# Altium schematic sheet DashboardDisplay_PSU_COM.SchDoc(DashboardDisplay_PSU_COM)
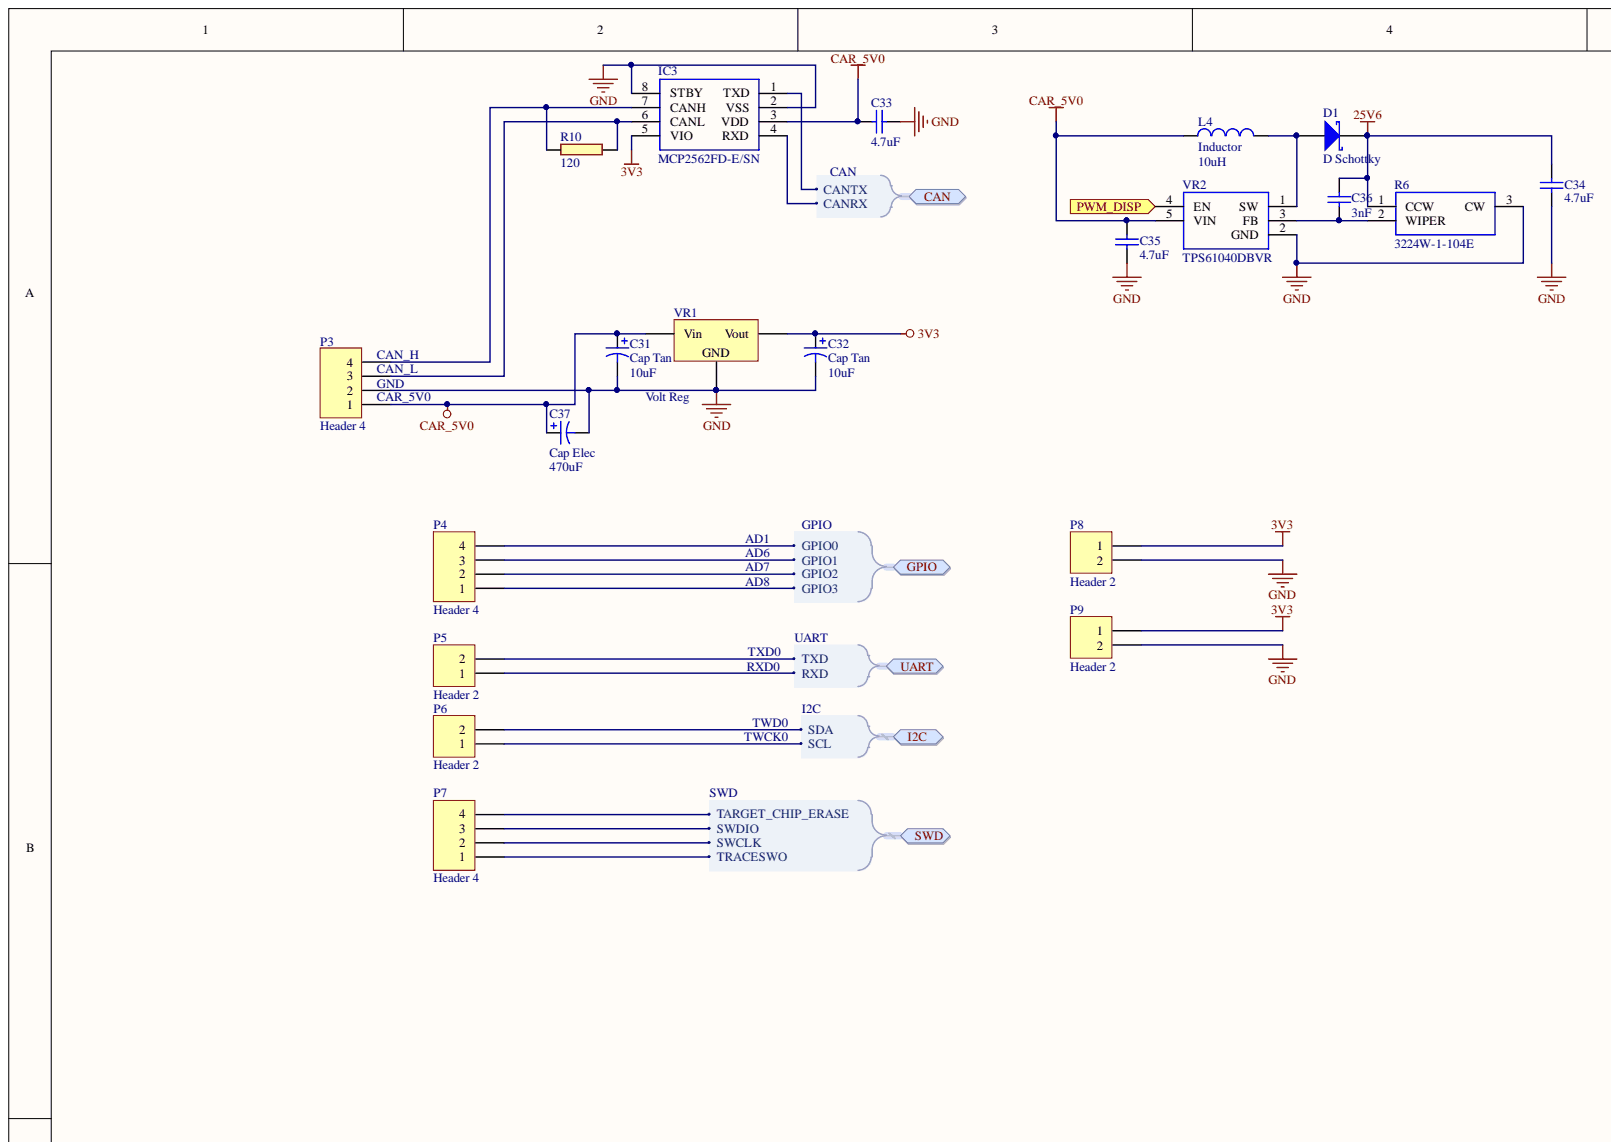
[diagram p0: DashboardDisplay_PSU_COM.SchDoc, top left]
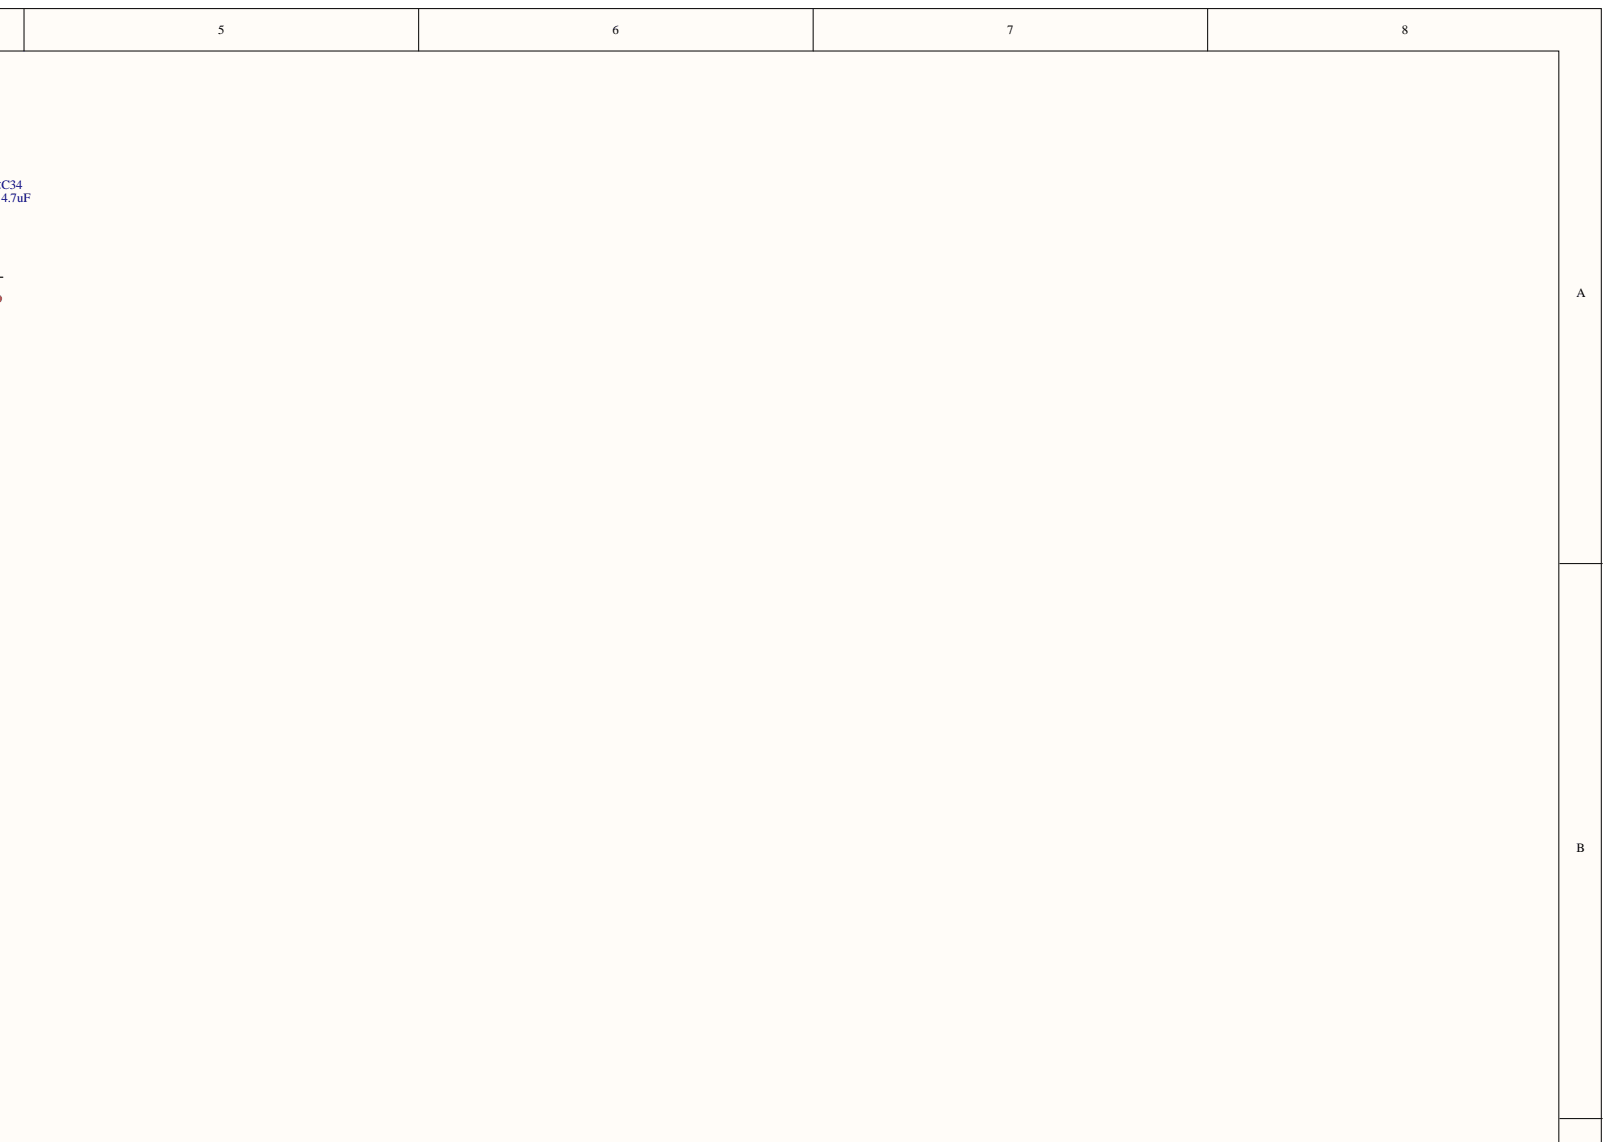
[diagram p1: DashboardDisplay_PSU_COM.SchDoc, top right]
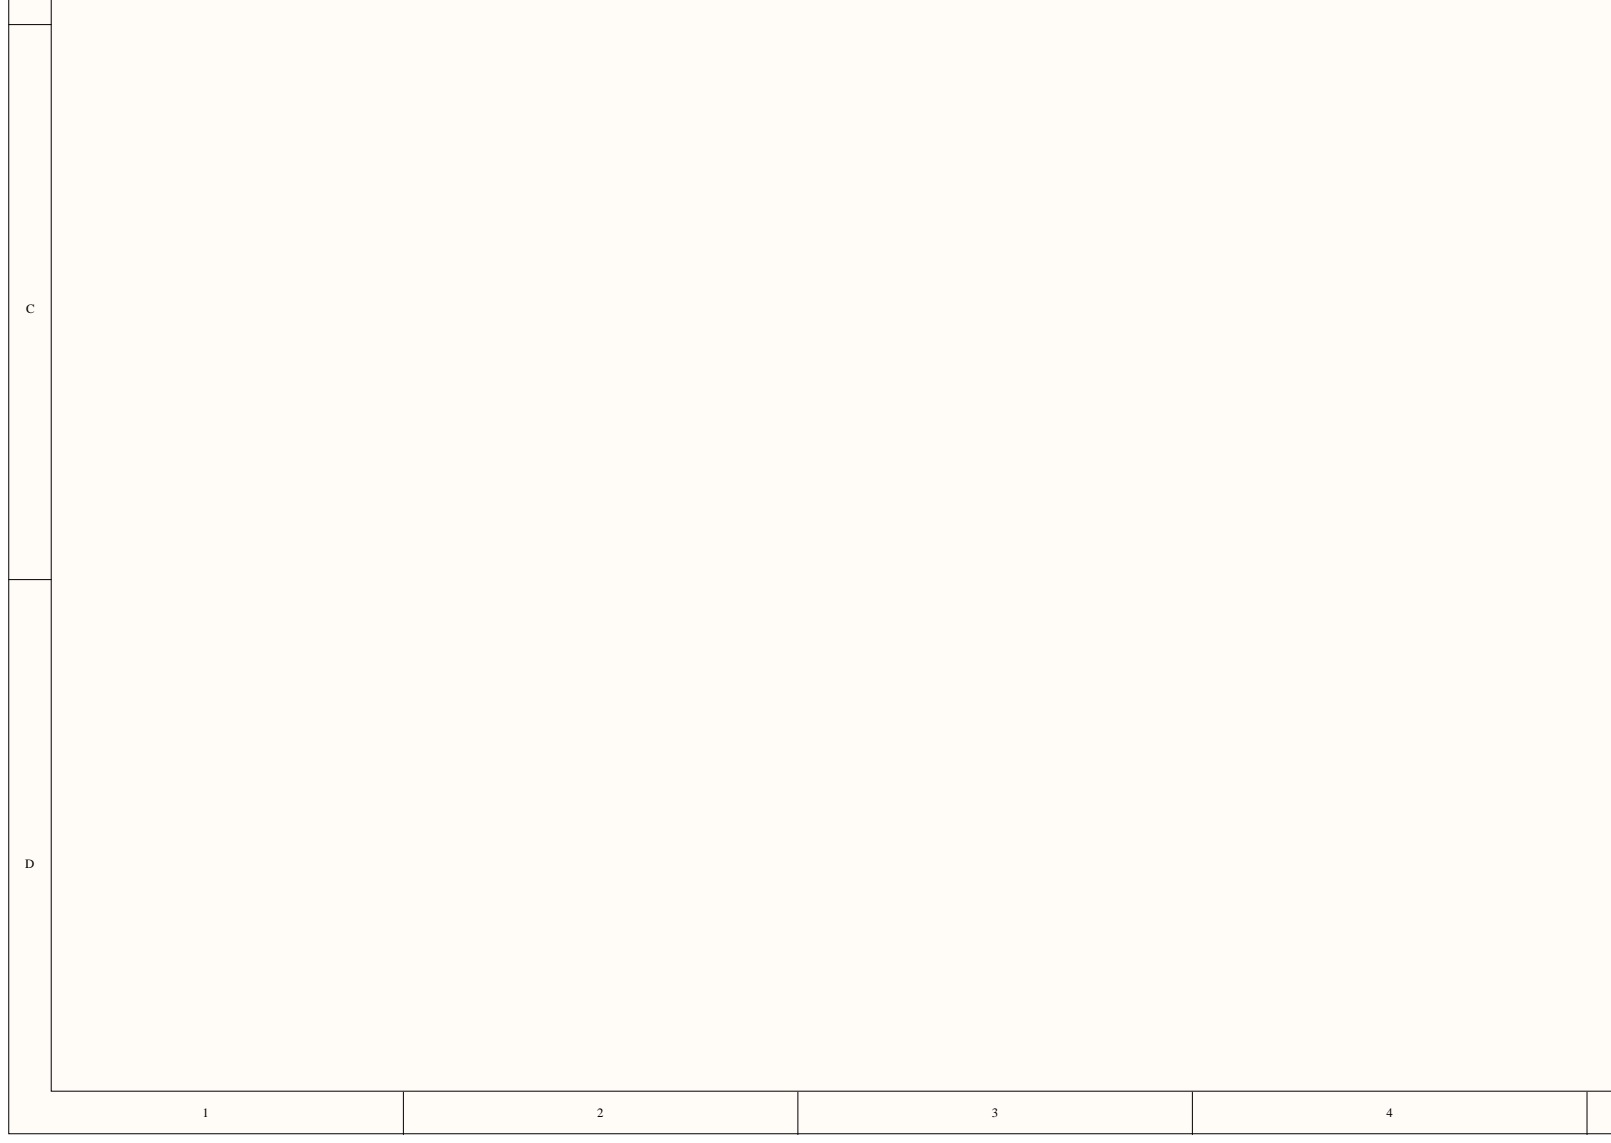
[diagram p2: DashboardDisplay_PSU_COM.SchDoc, bottom left]
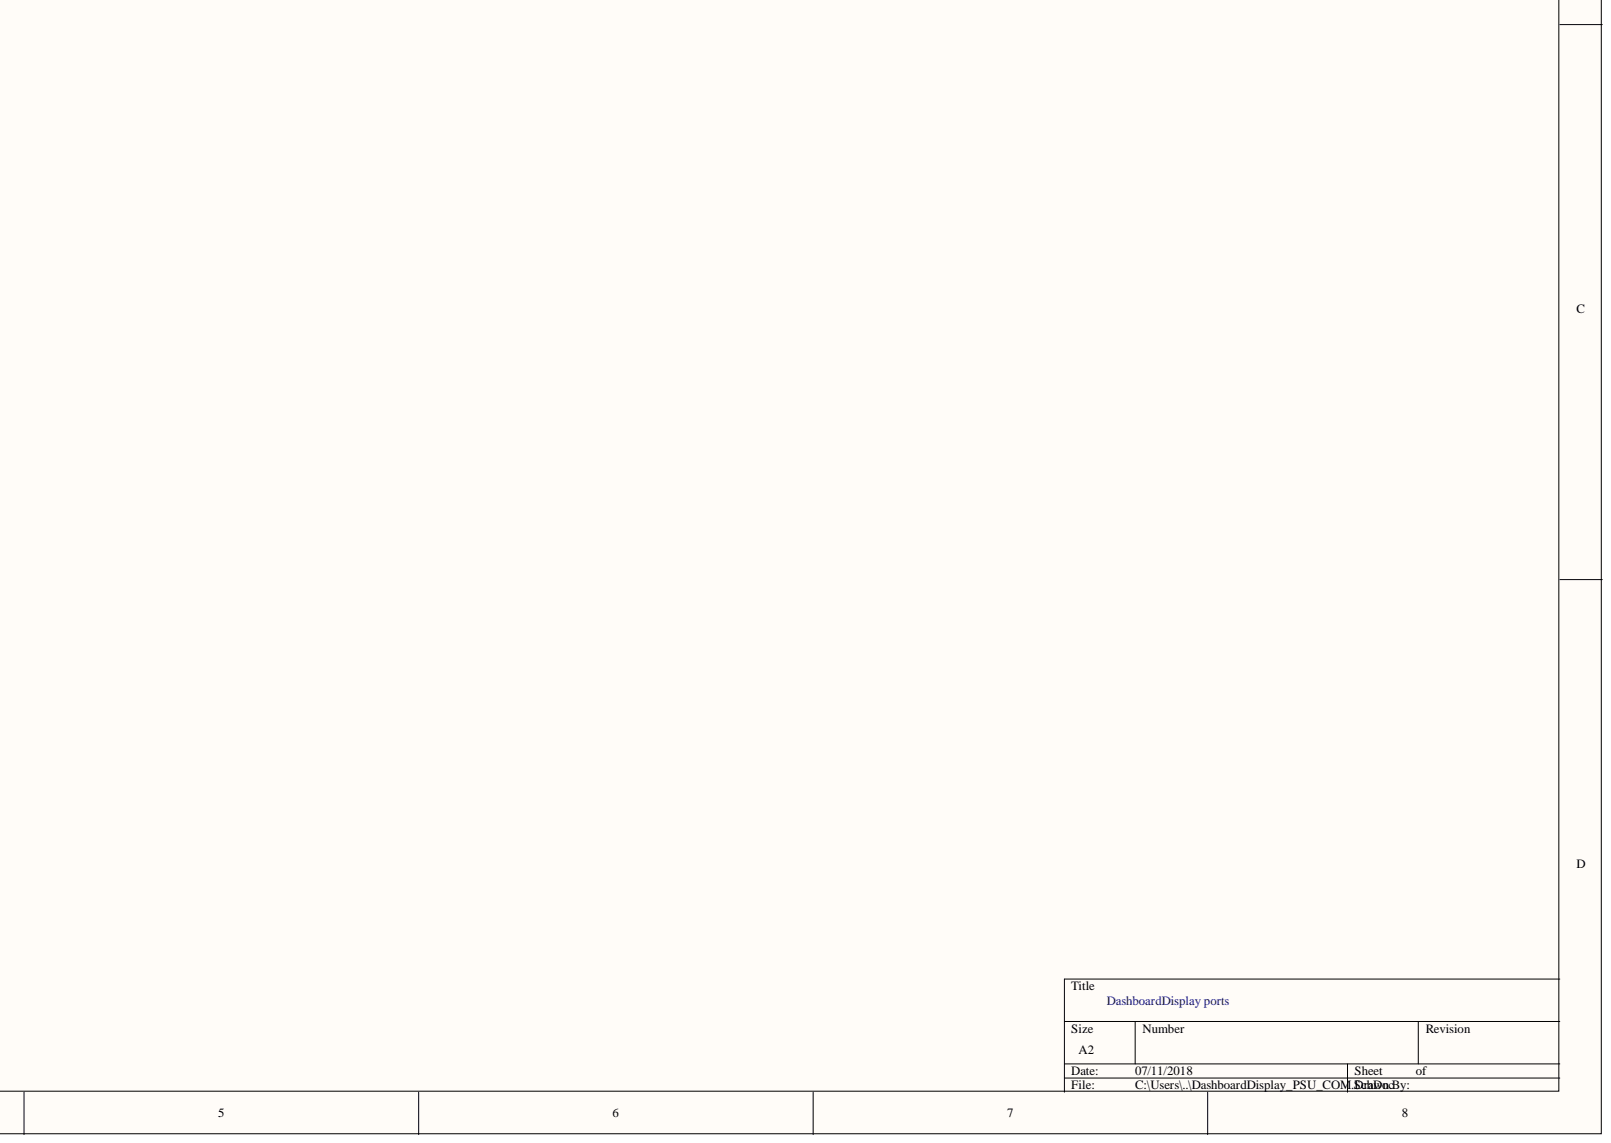
[diagram p3: DashboardDisplay_PSU_COM.SchDoc, bottom right]

## Parts
C31  Cap Tan  value 10uF  [C0805]
C32  Cap Tan  value 10uF  [C0805]
C33  value 4.7uF  [C1206]  (hidden comment: Cap Semi)
C34  value 4.7uF  [C1206]  (hidden comment: Cap Semi)
C35  value 4.7uF  [C1206]  (hidden comment: Cap Semi)
C36  value 3nF  [1608[0603]]  (hidden comment: Cap Semi)
C37  Cap Elec  value 470uF  [EEVFK2A151M]
D1  D Schottky  [SODFL350X110-2N]
IC3  MCP2562FD-E/SN  [SOIC127P600X175-8N]
L4  Inductor  value 10uH  [INDC3225X210N]
P3  Header 4  [SM04B-SRSS-TB(LFSN)]
P4  Header 4  [SM04B-SRSS-TB(LFSN)]
P5  Header 2  [SM02B-SRSS-TB]
P6  Header 2  [SM02B-SRSS-TB]
P7  Header 4  [SM04B-SRSS-TB(LFSN)]
P8  Header 2  [SM02B-SRSS-TB]
P9  Header 2  [SM02B-SRSS-TB]
R6  3224W-1-104E  [3224W-1-105E]
R10  value 120  [6-0805_L]
VR1  Volt Reg  [SOT223_L]
VR2  TPS61040DBVR  [SOT95P280X145-5N]

## Ports
CAN, CAN.CANRX, CAN.CANTX, GPIO, GPIO.GPIO0, GPIO.GPIO1, GPIO.GPIO2, GPIO.GPIO3, I2C, I2C.SCL, I2C.SDA, PWM_DISP, SWD, SWD.SWCLK, SWD.SWDIO, SWD.TARGET_CHIP_ERASE, SWD.TRACESWO, UART, UART.RXD, UART.TXD

## Nets
3V3: C32.1 IC3.5(VIO) P8.1 P9.1 VR1.2 VR1.4
25V6: C34.1 C36.2 D1.2 R6.1
CAR_5V0: C31.1 C33.2 C35.1 C37.1 IC3.3(VDD) L4.1 P3.1 VR1.3 VR2.5(VIN)
GND: C31.2 C32.2 C33.1 C34.2 C35.2 C37.2 IC3.2(VSS) IC3.8(STBY) P3.2 P3.5 P3.6 P4.5 P4.6 P5.3 P5.4 P6.3 P6.4 P7.5 P7.6 P8.2 P8.3 P8.4 P9.2 P9.3 P9.4 R6.3 VR1.1 VR2.2(GND)
PWM_DISP: VR2.4(EN) ; port PWM_DISP
NetC36_1: C36.1 R6.2 VR2.3(FB)
NetD1_1: D1.1 L4.2 VR2.1(SW)
NetIC3_1: IC3.1(TXD) ; port CAN
NetIC3_4: IC3.4(RXD) ; port CAN
NetIC3_6: IC3.6(CANL) P3.3 R10.2
NetIC3_7: IC3.7(CANH) P3.4 R10.1
NetP4_1: P4.1 ; port GPIO
NetP4_2: P4.2 ; port GPIO
NetP4_3: P4.3 ; port GPIO
NetP4_4: P4.4 ; port GPIO
NetP5_1: P5.1 ; port UART
NetP5_2: P5.2 ; port UART
NetP6_1: P6.1 ; port I2C
NetP6_2: P6.2 ; port I2C
NetP7_1: P7.1 ; port SWD
NetP7_2: P7.2 ; port SWD
NetP7_3: P7.3 ; port SWD
NetP7_4: P7.4 ; port SWD

## Library data of the parts
C31 C32 C37 = Cap Pol3: Polarized Capacitor (Surface Mount); Simulation: CAP; Signal Integrity: Cap Pol; Published: 8-Jun-2000; PackageReference: C0805; PackageDescription: Chip Capacitor; Library Name: Miscellaneous Devices.IntLib; LatestRevisionNote: IPC-7351 Footprint Added.; LatestRevisionDate: 29-May-2009; Component Kind: Standard; Publisher: Altium Limited
C33-C36 = Cap Semi: Capacitor (Semiconductor SIM Model); Simulation: CAP; Signal Integrity: Cap Semi; Published: 8-Jun-2000; PackageReference: C1206; PackageDescription: Chip Capacitor; Library Name: Miscellaneous Devices.IntLib; LatestRevisionNote: IPC-7351 Footprint Added.; LatestRevisionDate: 29-May-2009; Component Kind: Standard; Publisher: Altium Limited
D1 = D Schottky: Schottky Diode  MBR0530; Simulation: SKYDIODE; Signal Integrity: D Schottky; Published: 8-Jun-2000; PackageReference: SMB; PackageDescription: DO-214-AA/SMB; 2 C-Bend Leads; Body 5.3 x 3.6 mm, inc. leads (LxW); MPN: MBR0530; Library Name: Miscellaneous Devices.IntLib; LatestRevisionNote: Re-released for DXP Platform.; LatestRevisionDate: 17-Jul-2002; Component Kind: Standard; Code_JEDEC: DO-214-AA; Publisher: Altium Limited
IC3 = MCP2561-E_SN: CAN FD Transceiver 8Mbps 5V SOIC8 Microchip MCP2562FD-E/SN; Manufacturer_Part_Number: MCP2561-E/SN; Geometry.Height: 1.75mm; Allied_Number: 70415103; RS Price/Stock: http://uk.rs-online.com/web/p/products/8884871; RS Part Number: 8884871; Manufacturer_Name: Microchip; Library Name: MCP2561-E_SN.SchLib; Datasheet Link: http://uk.rs-online.com/web/p/products/7990216; Component Kind: Standard; Allied Price/Stock: https://www.alliedelec.com/microchip-technology-inc-mcp2561-e-sn/70415103/
L4 = Inductor: Inductor  LBC3225T100KRV; MPN: MLZ1608N100LT000; Value: 10uH; Code_IEC: 1005; Simulation: INDUCTOR; Signal Integrity: Inductor; Published: 8-Jun-2000; PackageReference: 0402-A; PackageDescription: Chip Inductor; Library Name: Miscellaneous Devices.IntLib; LatestRevisionNote: IPC-7351 Footprint Added.; LatestRevisionDate: 29-May-2009; Component Kind: Standard; Code_JEITA: 0402; Publisher: Altium Limited
P3 P4 P7 = Header 4: JST, 4-Pin; Signal Integrity: Connector; Library Name: Miscellaneous Connectors.IntLib; LatestRevisionNote: Re-released for DXP Platform.; LatestRevisionDate: 17-Jul-2002; Component Kind: Standard; Publisher: Altium Limited
P5 P6 P8 P9 = Header 2: JST, 2-Pin; Signal Integrity: Connector; Library Name: Miscellaneous Connectors.IntLib; LatestRevisionNote: Re-released for DXP Platform.; LatestRevisionDate: 17-Jul-2002; Component Kind: Standard; Publisher: Altium Limited
R6 = 3224W-1-104E: 3224W SMT topadj cermet trimmer,100K 4mm Bourns 3224W Series 12-Turn SMD Cermet Trimmer Resistor with J-Hook Terminations, 100k 10% 0.25W 100ppm/C Top Adjust; Allied_Number: 70153418; RS Price/Stock: http://uk.rs-online.com/web/p/products/0177510; RS Part Number: 0177510; Manufacturer_Part_Number: 3224W-1-104E; Manufacturer_Name: Bourns; Library Name: 3224W-1-104E.SchLib; Datasheet Link: https://componentsearchengine.com/Datasheets/1/3224W-1-104E.pdf; Component Kind: Standard; Arrow Price/Stock: https://www.arrow.com/en/products/3224w-1-104e/bourns; Arrow Part Number: 3224W-1-104E; Allied Price/Stock: https://www.alliedelec.com/bourns-3224w-1-104e/70153418/
R10 = A02-0260: Thick film resistor, SMD 0402, 1/16W, 1%; PartGroup: Resistors,SMD,0402 1%; MPN: CR10-1003-FK; Manufacturer: ASJ; TemperatureRange: -55ï¿½ to +125ï¿½C; Database Table Name: AltiumComponents; Tolerance: 1 %; Library Name: AltiumDbLib.DbLib; Height: 0,349999994039536; Component Kind: Standard; AN: A02-0260
VR1 = Volt Reg: Voltage Regulator; MPN: LM1117IMP-3.3; Published: 24-Mar-1999; PackageReference: D2PAK; PackageDescription: 3-Pin Transistor Outline Package 2.54 mm Pitch, 15.1 mm Lead Span; Library Name: Miscellaneous Devices.IntLib; LatestRevisionNote: IPC-7351 Footprint Added.; LatestRevisionDate: 29-May-2009; Component Kind: Standard; Publisher: Altium Limited
VR2 = TPS61040DBVR: Switch Boost Converter 28V 400mA for LCD Texas Instruments TPS61040DBVR, Step Up DC-DC Converter, 550mA, Adjustable, 1.8 ??? 28 V, 5-Pin SOT-23; Geometry.Height: 1.45mm; RS Price/Stock: http://uk.rs-online.com/web/p/products/7320695P; RS Part Number: 7320695P; Manufacturer_Part_Number: TPS61040DBVR; Manufacturer_Name: Texas Instruments; Library Name: TPS61040DBVR.SchLib; Datasheet Link: http://www.ti.com/lit/ds/symlink/tps61040.pdf; Component Kind: Standard; Arrow Price/Stock: https://www.arrow.com/en/products/tps61040dbvr/texas-instruments; Arrow Part Number: TPS61040DBVR
